# Altium schematic sheet v2.SchDoc
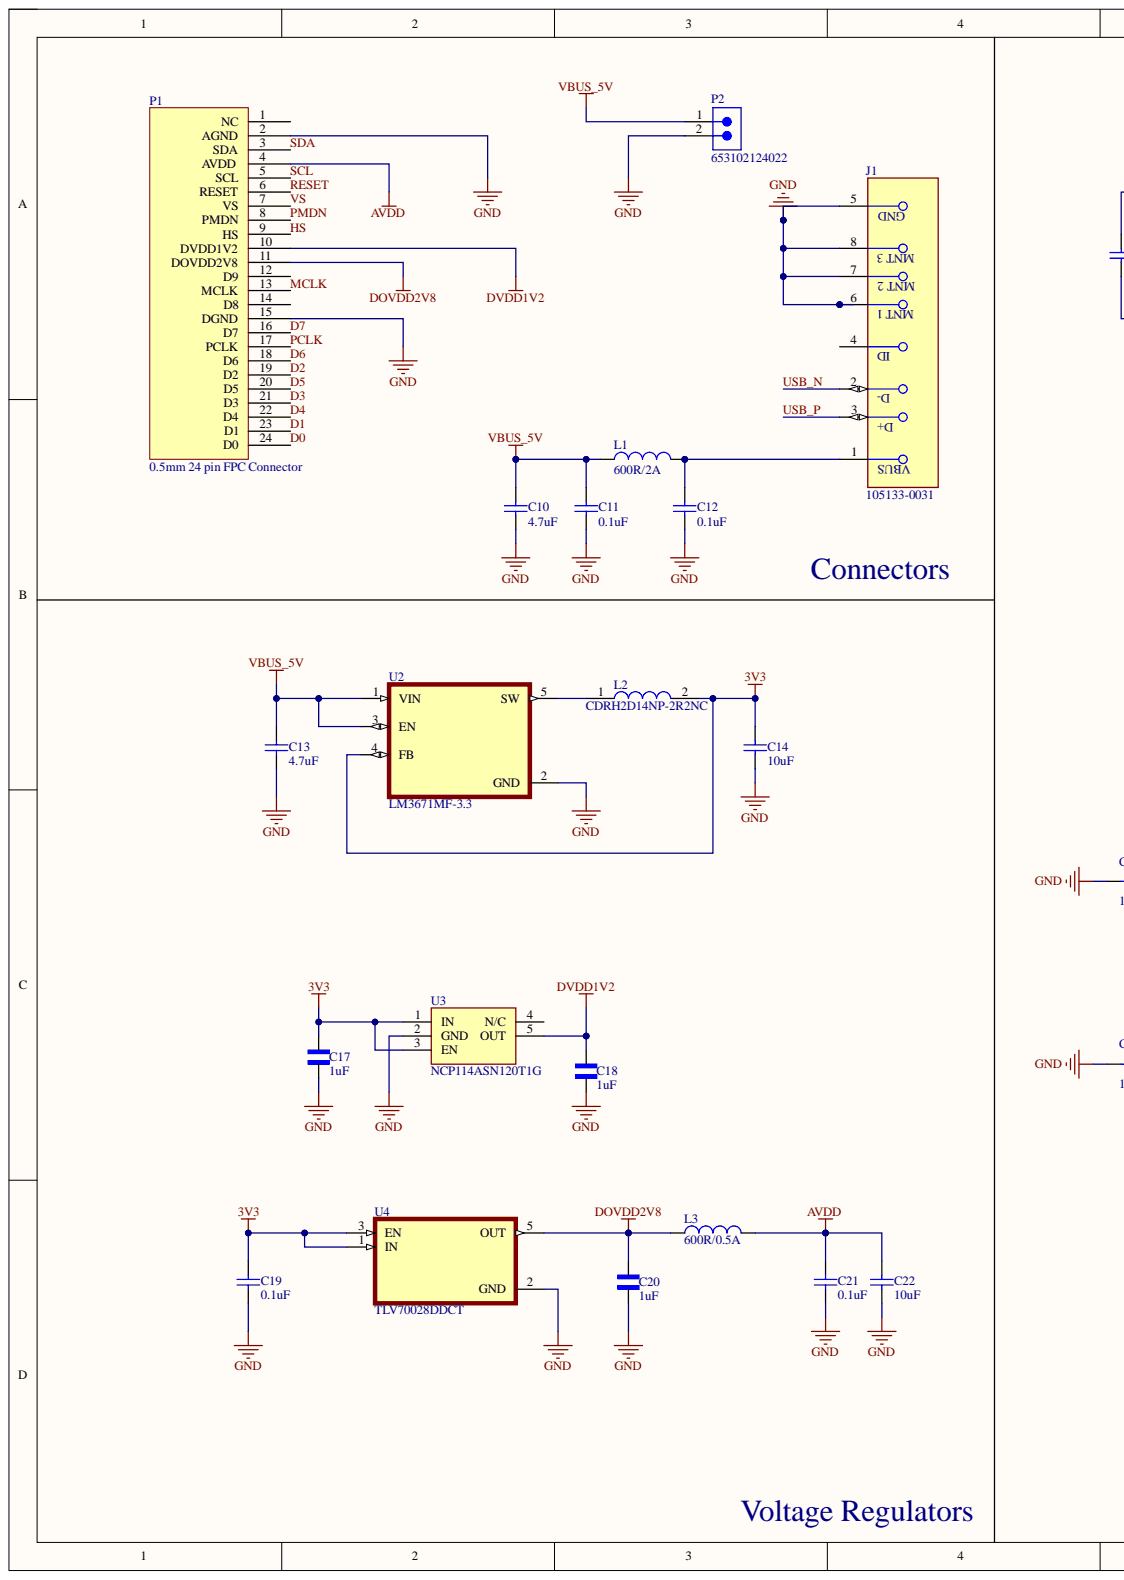
[diagram p0: v2.SchDoc, left]
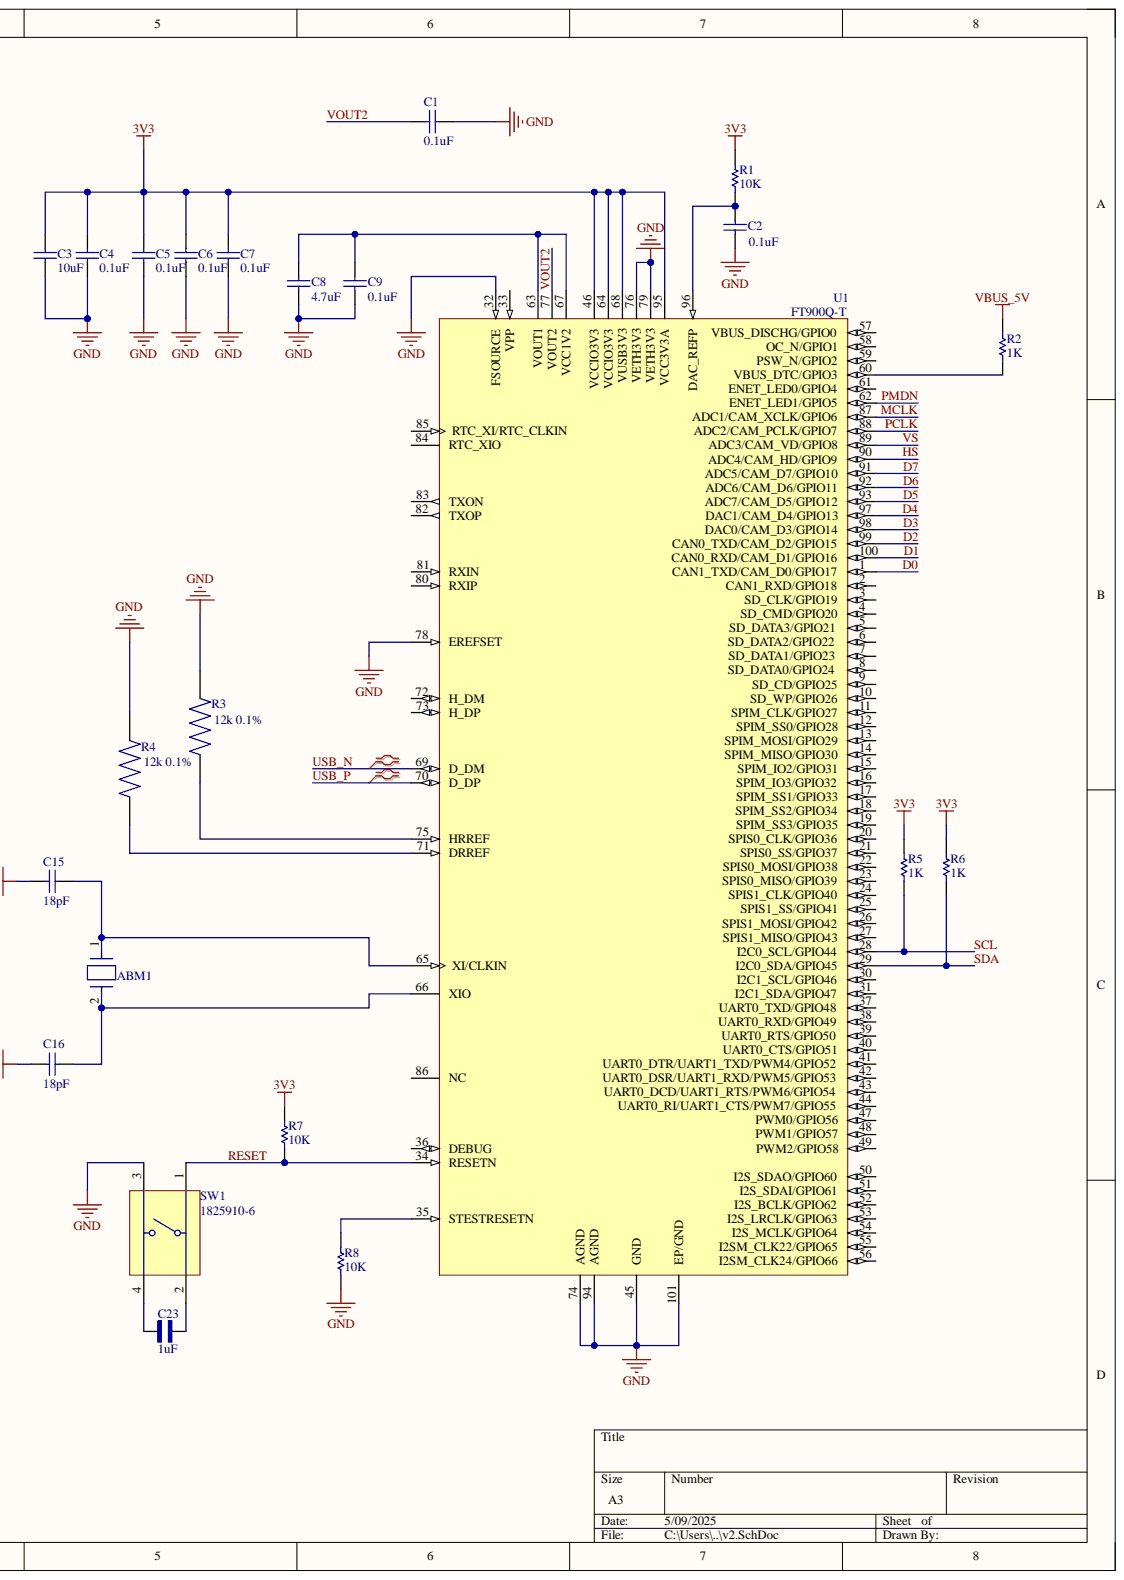
[diagram p1: v2.SchDoc, right]

## Parts
ABM1  ABM8G-12.000MHZ-18-D2Y-T  [XTAL_ABM8G-12.000MHZ-18-D2Y-T]  (value not drawn)
C1  value 0.1uF  [FP-GRM15-IPC_A]  (hidden comment: GRM155R71C104KA88D)
C2  value 0.1uF  [FP-GRM15-IPC_A]  (hidden comment: GRM155R71C104KA88D)
C3  value 10uF  [FP-GRM155-0_2-IPC_A]  (hidden comment: Capacitor)
C4  value 0.1uF  [FP-GRM15-IPC_A]  (hidden comment: GRM155R71C104KA88D)
C5  value 0.1uF  [FP-GRM15-IPC_A]  (hidden comment: GRM155R71C104KA88D)
C6  value 0.1uF  [FP-GRM15-IPC_A]  (hidden comment: GRM155R71C104KA88D)
C7  value 0.1uF  [FP-GRM15-IPC_A]  (hidden comment: GRM155R71C104KA88D)
C8  value 4.7uF  [FP-C1005-050-0_15-IPC_A]  (hidden comment: C1005X5R1A475M050BC TDK)
C9  value 0.1uF  [FP-GRM15-IPC_A]  (hidden comment: GRM155R71C104KA88D)
C10  value 4.7uF  [FP-C1005-050-0_15-IPC_A]  (hidden comment: C1005X5R1A475M050BC TDK)
C11  value 0.1uF  [FP-GRM15-IPC_A]  (hidden comment: GRM155R71C104KA88D)
C12  value 0.1uF  [FP-GRM15-IPC_A]  (hidden comment: GRM155R71C104KA88D)
C13  value 4.7uF  [FP-C1005-050-0_15-IPC_A]  (hidden comment: C1005X5R1A475M050BC TDK)
C14  value 10uF  [FP-GRM155-0_2-IPC_A]  (hidden comment: Capacitor)
C15  value 18pF  [CAPC0402X22N]  (hidden comment: GRM0225C1H180JA02L)
C16  value 18pF  [CAPC0402X22N]  (hidden comment: GRM0225C1H180JA02L)
C17  1uF  [FP-C0402C105K8PACTU-IPC_A]
C18  1uF  [FP-C0402C105K8PACTU-IPC_A]
C19  value 0.1uF  [FP-GRM15-IPC_A]  (hidden comment: GRM155R71C104KA88D)
C20  1uF  [FP-C0402C105K8PACTU-IPC_A]
C21  value 0.1uF  [FP-GRM15-IPC_A]  (hidden comment: Capacitor)
C22  value 10uF  [FP-GRM155-0_2-IPC_A]  (hidden comment: Capacitor)
C23  1uF  [FP-C0402C105K8PACTU-IPC_A]
J1  105133-0031  [FP-105133-0031-MFG]
L1  value 600R/2A  [BEADC2012X105N]  (hidden comment: MPZ2012S601AT000)
L2  CDRH2D14NP-2R2NC  [CDRH2D14]
L3  value 600R/0.5A  [FP-MMZ2012-MFG]  (hidden comment: MMZ2012R601AT000)
P1  0.5mm 24 pin FPC Connector  [68712414022]
P2  653102124022  [653102124022]
R1  value 10K  [RESC1005X40N]  (hidden comment: RC0402FR-0710KL Yageo)
R2  value 1K  [RESC1005X40N]  (hidden comment: RC0402FR-071KL Yageo)
R3  12k 0.1%  [RES_ERA3AEB123V]
R4  12k 0.1%  [RES_ERA3AEB123V]
R5  value 1K  [RESC1005X40N]  (hidden comment: RC0402FR-071KL Yageo)
R6  value 1K  [RESC1005X40N]  (hidden comment: RC0402FR-071KL Yageo)
R7  value 10K  [RESC1005X40N]  (hidden comment: RC0402FR-0710KL Yageo)
R8  value 10K  [RESC1005X40N]  (hidden comment: RC0402FR-0710KL)
SW1  1825910-6  [TECO-1825910-6_V]
U1  FT900Q-T  [QFN-100]
U2  LM3671MF-3.3  [SOT95P280X145-5N]
U3  NCP114ASN120T1G  [SOT95P275X110-5N]
U4  TLV70028DDCT  [SOT95P280X110-5N]

## Nets
3V3: C3.1 C4.1 C5.1 C6.1 C7.1 C14.1 C17.2 C19.2 L2.2 R1.1 R5.2 R6.2 R7.1 U1.46(VCCIO3V3) U1.64(VCCIO3V3) U1.68(VUSB3V3) U1.95(VCC3V3A) U2.4(FB) U3.1(IN) U3.3(EN) U4.1(IN) U4.3(EN)
AVDD: C21.1 C22.2 L3.2 P1.4(AVDD)
DOVDD2V8: C20.2 L3.1 P1.11(DOVDD2V8) U4.5(OUT)
DVDD1V2: C18.2 P1.10(DVDD1V2) U3.5(OUT)
GND: C1.2 C2.2 C3.2 C4.2 C5.2 C6.2 C7.2 C8.2 C9.2 C10.2 C11.2 C12.2 C13.2 C14.2 C15.2 C16.2 C17.1 C18.1 C19.1 C20.1 C21.2 C22.1 J1.5 J1.6 J1.7 J1.8 P1.2(AGND) P1.15(DGND) P2.2 R3.2 R4.2 R8.2 SW1.3 U1.32(FSOURCE) U1.45(GND) U1.74(AGND) U1.76(VETH3V3) U1.78(EREFSET) U1.79(VETH3V3) U1.94(AGND) U1.101(EP/GND) U2.2(GND) U3.2(GND) U4.2(GND)
VBUS_5V: C10.1 C11.1 C13.1 L1.1 P2.1 R2.2 U2.1(VIN) U2.3(EN)
D0: P1.24(D0) U1.1(CAN1_TXD/CAM_D0/GPIO17)
D1: P1.23(D1) U1.100(CAN0_RXD/CAM_D1/GPIO16)
D2: P1.19(D2) U1.99(CAN0_TXD/CAM_D2/GPIO15)
D3: P1.21(D3) U1.98(DAC0/CAM_D3/GPIO14)
D4: P1.22(D4) U1.97(DAC1/CAM_D4/GPIO13)
D5: P1.20(D5) U1.93(ADC7/CAM_D5/GPIO12)
D6: P1.18(D6) U1.92(ADC6/CAM_D6/GPIO11)
D7: P1.16(D7) U1.91(ADC5/CAM_D7/GPIO10)
HS: P1.9(HS) U1.90(ADC4/CAM_HD/GPIO9)
MCLK: P1.13(MCLK) U1.87(ADC1/CAM_XCLK/GPIO6)
PCLK: P1.17(PCLK) U1.88(ADC2/CAM_PCLK/GPIO7)
PMDN: P1.8(PMDN) U1.62(ENET_LED1/GPIO5)
RESET: P1.6(RESET) R7.2 SW1.1 U1.34(RESETN)
SCL: P1.5(SCL) R5.1 U1.28(I2C0_SCL/GPIO44)
SDA: P1.3(SDA) R6.1 U1.29(I2C0_SDA/GPIO45)
USB_N: J1.2 U1.69(D_DM)
USB_P: J1.3 U1.70(D_DP)
VOUT2: C1.1 U1.77(VOUT2)
VS: P1.7(VS) U1.89(ADC3/CAM_VD/GPIO8)
NetABM1_1: ABM1.1 C15.1 U1.65(XI/CLKIN)
NetABM1_2: ABM1.2 C16.1 U1.66(XIO)
NetC2_1: C2.1 R1.2 U1.96(DAC_REFP)
NetC8_1: C8.1 C9.1 U1.63(VOUT1) U1.67(VCC1V2)
NetC12_1: C12.1 J1.1 L1.2
NetC23_1: C23.1 SW1.2
NetC23_2: C23.2 SW1.4
NetL2_1: L2.1 U2.5(SW)
NetR2_1: R2.1 U1.60(VBUS_DTC/GPIO3)
NetR3_1: R3.1 U1.75(HRREF)
NetR4_1: R4.1 U1.71(DRREF)
NetR8_1: R8.1 U1.35(STESTRESETN)

Not connected: J1 4; P1 1(NC) 12(D9) 14(D8); U1 2(CAN1_RXD/GPIO18) 3(SD_CLK/GPIO19) 4(SD_CMD/GPIO20) 5(SD_DATA3/GPIO21) 6(SD_DATA2/GPIO22) 7(SD_DATA1/GPIO23) 8(SD_DATA0/GPIO24) 9(SD_CD/GPIO25) 10(SD_WP/GPIO26) 11(SPIM_CLK/GPIO27) 12(SPIM_SS0/GPIO28) 13(SPIM_MOSI/GPIO29) 14(SPIM_MISO/GPIO30) 15(SPIM_IO2/GPIO31) 16(SPIM_IO3/GPIO32) 17(SPIM_SS1/GPIO33) 18(SPIM_SS2/GPIO34) 19(SPIM_SS3/GPIO35) 20(SPIS0_CLK/GPIO36) 21(SPIS0_SS/GPIO37) 22(SPIS0_MOSI/GPIO38) 23(SPIS0_MISO/GPIO39) 24(SPIS1_CLK/GPIO40) 25(SPIS1_SS/GPIO41) 26(SPIS1_MOSI/GPIO42) 27(SPIS1_MISO/GPIO43) 30(I2C1_SCL/GPIO46) 31(I2C1_SDA/GPIO47) 33(VPP) 36(DEBUG) 37(UART0_TXD/GPIO48) 38(UART0_RXD/GPIO49) 39(UART0_RTS/GPIO50) 40(UART0_CTS/GPIO51) 41(UART0_DTR/UART1_TXD/PWM4/GPIO52) 42(UART0_DSR/UART1_RXD/PWM5/GPIO53) 43(UART0_DCD/UART1_RTS/PWM6/GPIO54) 44(UART0_RI/UART1_CTS/PWM7/GPIO55) 47(PWM0/GPIO56) 48(PWM1/GPIO57) 49(PWM2/GPIO58) 50(I2S_SDAO/GPIO60) 51(I2S_SDAI/GPIO61) 52(I2S_BCLK/GPIO62) 53(I2S_LRCLK/GPIO63) 54(I2S_MCLK/GPIO64) 55(I2SM_CLK22/GPIO65) 56(I2SM_CLK24/GPIO66) 57(VBUS_DISCHG/GPIO0) 58(OC_N/GPIO1) 59(PSW_N/GPIO2) 61(ENET_LED0/GPIO4) 72(H_DM) 73(H_DP) 80(RXIP) 81(RXIN) 82(TXOP) 83(TXON) 84(RTC_XIO) 85(RTC_XI/RTC_CLKIN) 86(NC); U3 4(N/C)

## Library data of the parts
ABM1 = ECS-120-18-5PXDU-TR: 3225 12MHz 18pF ±20ppm -40°C~85°C; Component Kind: Standard; Library Name: parts/Crys_ECS_International_ECS-120-18-5PXDU-TR_eec.SchLib
C1 C2 C4 C6 C7 C9 C11 C12 C19 C21 = Capacitor: Ceramic Capacitor, Multilayer, Ceramic, 16V, 10% +Tol, 10% -Tol, X7R, 15% TC, 0.1uF, Surface Mount, 0402; SimulationGenericComponent: True; Component Kind: Standard; Library Name: Simulation Generic Components.IntLib; Simulation: C_Capacitor_Default
C3 C14 C22 = Capacitor: GRM155R61A106ME11D, Murata, Ceramic Capacitor, Multilayer, Ceramic, 10V, 20% +Tol, 20% -Tol, X5R, 15% TC, 10uF, Surface Mount, 0402; SimulationGenericComponent: True; Component Kind: Standard; Library Name: Simulation Generic Components.IntLib; Simulation: C_Capacitor_Default
C5 = Capacitor: GRM155R71C104KA88D Ceramic Capacitor, Multilayer, Ceramic, 16V, 10% +Tol, 10% -Tol, X7R, 15% TC, 0.1uF, Surface Mount, 0402; SimulationGenericComponent: True; Component Kind: Standard; Library Name: Simulation Generic Components.IntLib; Simulation: C_Capacitor_Default
C8 C10 C13 = Capacitor: Ceramic Capacitor, Multilayer, Ceramic, 10V, 20% +Tol, 20% -Tol, X5R, 15% TC, 4.7uF, Surface Mount, 0402; SimulationGenericComponent: True; Component Kind: Standard; Library Name: Simulation Generic Components.IntLib; Simulation: C_Capacitor_Default
C15 C16 = Capacitor: Ceramic Capacitor, Multilayer, Ceramic, 50V, 5% +Tol, 5% -Tol, C0G, 30ppm/Cel TC, 0.000018uF, Surface Mount, 01005; SimulationGenericComponent: True; Component Kind: Standard; Library Name: Simulation Generic Components.IntLib; Simulation: C_Capacitor_Default
C17 C18 C20 C23 = GRT033D70J105ME13D: SMD Capacitor X7T(EIA) with Capacitance: 1.0uF Tol. 20%. Rated Voltage: 25Vdc; MF: Murata; Check_prices: https://www.snapeda.com/parts/GRT033D70J105ME13D/Murata/view-part/?ref=eda; Component Kind: Standard; Library Name: GRT033D70J105ME13D.IntLib; MP: GRT033D70J105ME13D; Package: 0603 Murata; SnapEDA_Link: https://www.snapeda.com/parts/GRT033D70J105ME13D/Murata/view-part/?ref=snap
J1 = CMP-00257-66773964-1: 105133-0031 Micro-USB B Receptacle with Flange, Vertical, Surface Mount, 9.80mm Height, 2.30mm Solder Tabs, Lead-Free; Manufacturer Lifecycle Status: ACTIVE; Gender: Female; Number of Circuits: 5; Pitch: 650µm; Plating: Gold; Component Kind: Standard; Contact Plating: Gold; Country of Origin: CN; Housing Material: Thermoplastic; Length: 8mm; Library Name: Altium Content Vault; Lifecycle Status: Production; Max Operating Temperature: 85°C; Min Operating Temperature: -30°C; Mount: Surface Mount; NexarPartId: 66773964; Number of Pins: 5; Number of Rows: 1; Orientation: Vertical; RoHS: Compliant; Schedule B: 8536695050, 8536695050; Termination: Solder
L1 = Inductor: ferrite bead 600R/2A; SimulationGenericComponent: True; Component Kind: Standard; Library Name: Simulation Generic Components.IntLib; Simulation: L_Inductor_Default
L2 = CDRH2D14NP-2R2NC: General Purpose Inductor, 2.2uH, 30%, 1 Element, Ferrite-Core, SMD, 1212; Manufacturer_Name: Sumida; Arrow Part Number: CDRH2D14NP-2R2NC; Arrow Price/Stock: https://www.arrow.com/en/products/cdrh2d14np-2r2nc/sumida?region=nac; Component Kind: Standard; Datasheet Link: http://www.mouser.com/ds/2/390/CDRH2D14-523410.pdf; Library Name: SamacSys.SchLib; Manufacturer_Part_Number: CDRH2D14NP-2R2NC; Mouser Part Number: 851-CDRH2D14NP-2R2NC; Mouser Price/Stock: https://www.mouser.co.uk/ProductDetail/Sumida/CDRH2D14NP-2R2NC?qs=yEEOfDmvQDXT35Jdz6aZxg%3D%3D
L3 = Inductor: ferrite bead 600R/0.5A; SimulationGenericComponent: True; Component Kind: Standard; Library Name: Simulation Generic Components.IntLib; Simulation: L_Inductor_Default
P2 = CMP-1502-00757-2: 2P JST male terminal header; NexarPartId: 18818273; Connector Type: Male Pin; Contact Resistance: 20mΩ; Current Rating: 1A; Insulation: Insulated; Insulation Resistance: 100MΩ; Number of Contacts: 2; Operating Supply Voltage: 125V; Pitch: 1.25mm; Color: Beige, White; Component Kind: Standard; Contact Gender: Male; Contact Material: Copper; Contact Mating Length: 2.159mm; Contact Plating: Tin; Flammability Rating: UL94 V-0; Gender: Male; Height: 4.75mm; Housing Material: Nylon; Lead Pitch: 1.25mm; Length: 7.25mm; Library Name: Altium Content Vault; Max Operating Temperature: 85°C; Min Operating Temperature: -40°C; Mount: Surface Mount; Number of Pins: 2; Number of Positions: 2; Number of Rows: 1; Orientation: Vertical; Packaging: Tape and Reel; REACH SVHC: Unknown; RoHS: Compliant; Termination: SMD/SMT; Voltage Rating: 125V; Width: 7.25mm
R1 R7 R8 = Resistor: Fixed Resistor, Metal Glaze/thick Film, 0.0625W, 10000ohm, 50V, 1% +/-Tol, 100ppm/Cel, Surface Mount, 0402; SimulationGenericComponent: True; TC1: 0; Component Kind: Standard; Library Name: Simulation Generic Components.IntLib; Simulation: R_Resistor_Default; TC2: 0
R2 R5 R6 = Resistor: Fixed Resistor, Metal Glaze/thick Film, 0.0625W, 1000ohm, 50V, 1% +/-Tol, 100ppm/Cel, Surface Mount, 0402; SimulationGenericComponent: True; TC1: 0; Component Kind: Standard; Library Name: Simulation Generic Components.IntLib; Simulation: R_Resistor_Default; TC2: 0
R3 R4 = ERA3AEB123V: 12 kOhms Â±0.1% 0.1W, 1/10W Chip Resistor 0603 (1608 Metric) Automotive AEC-Q200 Thin Film; MF: Panasonic; Check_prices: https://www.snapeda.com/parts/ERA3AEB123V/Panasonic/view-part/?ref=eda; Component Kind: Standard; Digi-Key_Part_Number: P12KDBTR-ND; Library Name: ERA3AEB123V.IntLib; MP: ERA3AEB123V; Package: 1608-2 Panasonic; SnapEDA_Link: https://www.snapeda.com/parts/ERA3AEB123V/Panasonic/view-part/?ref=snap
SW1 = CMP-1684-00021-1: Tact Switch, SPST-NO, 0.05 A, -35 to 85 degC, 4-Pin THD, RoHS, Bulk; Manufacturer: TE Connectivity; Actuator Color: Black; Actuator Length: 1.4mm; Actuator Material: PolybutyleneTerephthalate; Actuator Style: RoundButton; Body Orientation: Straight; Bushing Type: Unthreaded; Contact Rating @ Voltage: 0.05A@24VDC; Dielectric Strength: 500VAC; Electrical Life: 100000Cycles; Function: MomentaryContact; Illumination: N/R; Maximum Contact Resistance: 100mOhm; Maximum DC Voltage Rating: 24V; Pole Throw Configuration: SPST; Switch Configuration: OFF(ON); Base Material: N/A; Component Kind: Standard; Contact Material: CopperAlloy; Contact Plating: Silver; Datasheet URL: http://www.te.com/commerce/DocumentDelivery/DDEController?Action=showdoc&DocId=Customer+Drawing%7F1825910%7FC9%7Fpdf%7FEnglish%7FENG_CD_1825910_C9.pdf%7F1825910-6; Datasheet Version: Rev. C9; Dimension: 5.99x5mm; Family: 1825910; Housing Material: N/A; Library Name: Altium Content Vault; Manufacturer URL: http://www.te.com; Maximum AC Voltage Rating: N/A; Mechanical Life: 100000Cycles; Minimum Insulation Resistance: 100MOhm; Mounting: ThroughHole; Operating Temperature: -35to85C; Package Description: 4-Pin Through Hole Device, Body 5.99 x 5.99 mm, Pitch 6.5 mm; Package Reference: THD; Packaging: N/A; Part No: 1825910-6; Product Weight: N/A; RoHS: Yes; Seal Description: N/A; Seal Location: N/A; Terminal Type: PCPins; Thread Size: N/R
U1 = CMP-0078-00067-1: FT900 Embedded Microcontroller, support both CAN Bus and Ethernet features, -40 to +85 degC, 100-Pin QFN, Pb-Free, Tray; Manufacturer: FTDI; Component Kind: Standard; ComponentLink1Description: Manufacturer URL; ComponentLink1URL: http://www.ftdichip.com/; ComponentLink2Description: Datasheet; ComponentLink2URL: http://www.ftdichip.com/Support/Documents/DataSheets/ICs/DS_FT900_1_2_3.pdf; DatasheetVersion: ver 1.1, Sep-2015; Library Name: Altium Content Vault; PackageDescription: 100-Pin Quad Flat No-Lead, 12 x 12 mm Body, 0.4 mm Pitch, 8 x 8 mm Exposed Pad; PackageReference: QFN-100
U2 = LM3671MF-3.3: 2MHz, 600mA Step-Down DC-DC Converter, switching regulator; MF: Texas Instruments; MP: LM3671MF-3.3/NOPB; Check_prices: https://www.snapeda.com/parts/LM3671MF-3.3/NOPB/Texas+Instruments/view-part/?ref=eda; Component Kind: Standard; Library Name: LM3671MF-3.3.IntLib; Package: SOT-23-5 Texas Instruments; SnapEDA_Link: https://www.snapeda.com/parts/LM3671MF-3.3/NOPB/Texas+Instruments/view-part/?ref=snap
U3 = NCP114ASN120T1G: LDO Fixed output 1V2; Manufacturer_Name: onsemi; Arrow Part Number: NCP114ASN120T1G; Arrow Price/Stock: https://www.arrow.com/en/products/ncp114asn120t1g/on-semiconductor?utm_currency=USD&region=nac; Component Kind: Standard; Datasheet Link: https://www.onsemi.com/pub/Collateral/NCP114-D.PDF; Height: 1.1mm; Library Name: SamacSys.SchLib; Manufacturer_Part_Number: NCP114ASN120T1G; Mouser Part Number: 863-NCP114ASN120T1G; Mouser Price/Stock: https://www.mouser.co.uk/ProductDetail/onsemi/NCP114ASN120T1G?qs=OycAS1CGnlje50hXK0O4%252Bw%3D%3D
U4 = TLV70028DDCT: LDO fixed output 2V8; Availability: Unavailable; Component Kind: Standard; Library Name: TLV70028DDCT.IntLib; MP: TLV70028DDCT; Package: None; Price: None
P1 = 0.5mm 24 pin FPC Connector: Component Kind: Standard; Library Name: 24pin_FPC_connector.SchLib
